# Altium schematic sheet RECEIVER DJI FPV SLAVE.SchDoc("RECEIVER DJI FPV SLAVE")
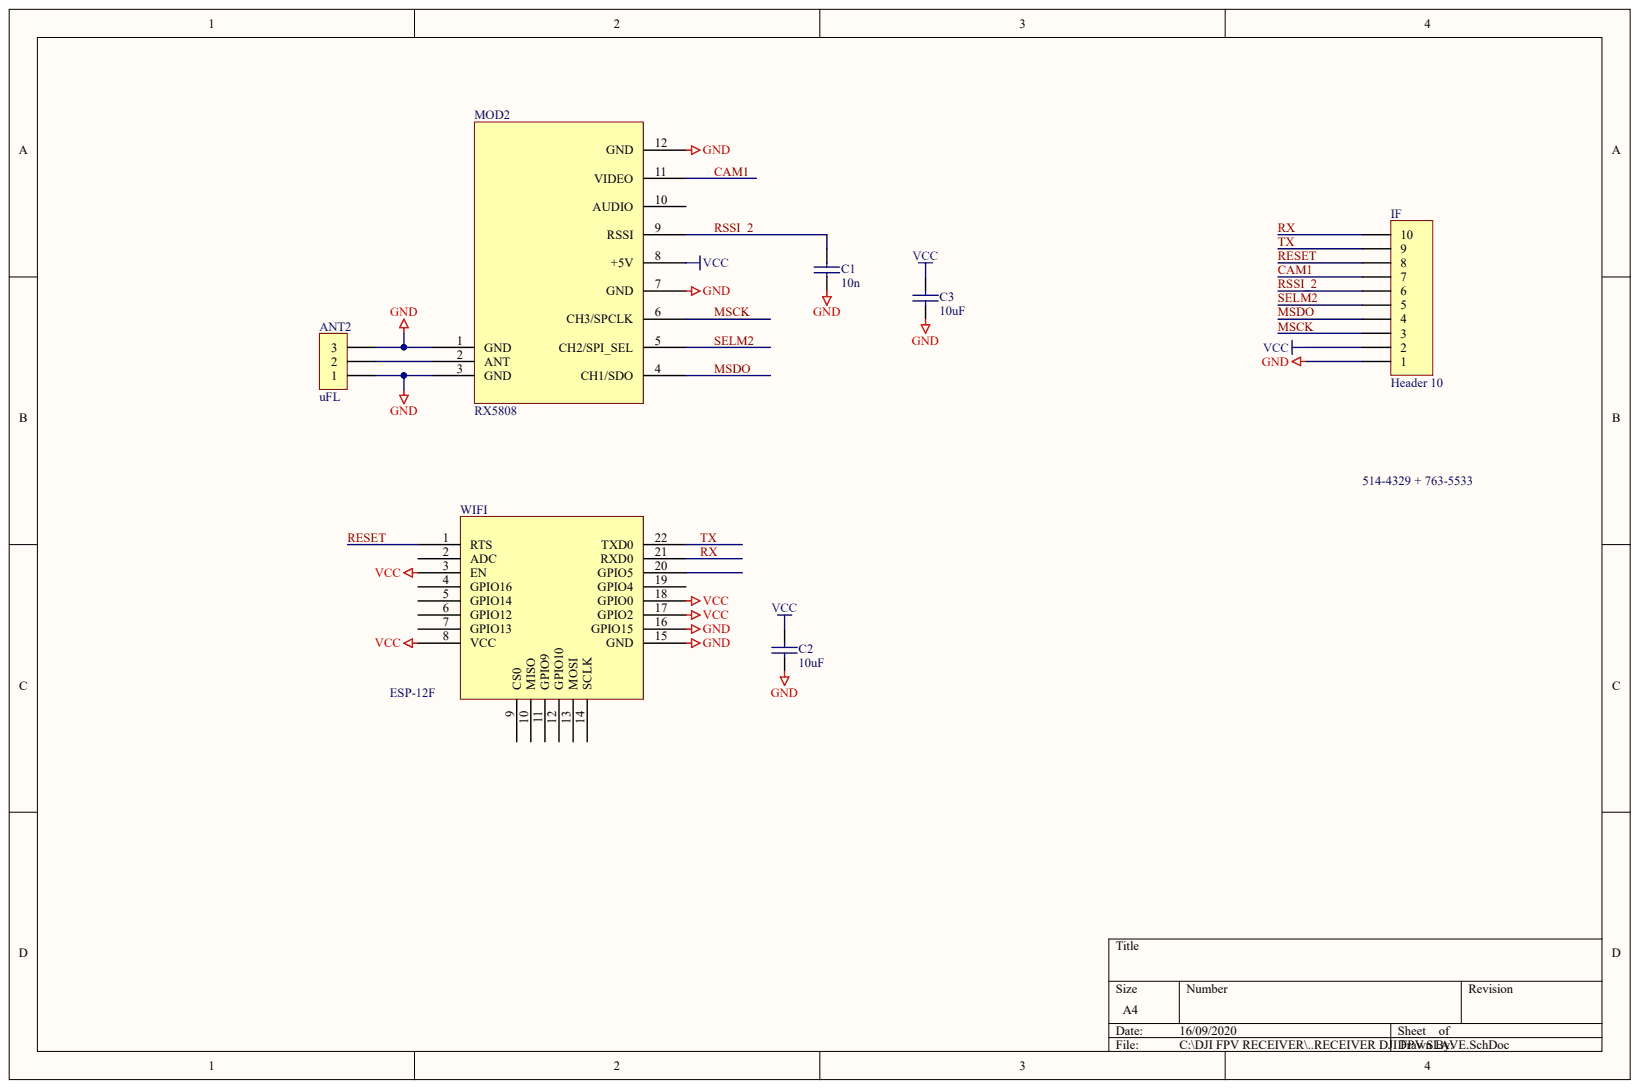
[diagram p0: RECEIVER DJI FPV SLAVE.SchDoc]

## Parts
ANT2  uFL  [ufl]
C1  10n  [0805]
C2  10uF  [0805]
C3  10uF  [0805]
IF  Header 10  [ziff10]
MOD2  RX5808  [rx5808s]
WIFI  ESP-12F  [ESP-12F]

## Nets
GND: ANT2.1 ANT2.3 C1.2 C2.2 C3.2 IF.1 MOD2.1(GND) MOD2.3(GND) MOD2.7(GND) MOD2.12(GND) WIFI.15(GND) WIFI.16(GPIO15)
VCC: C2.1 C3.1 IF.2 MOD2.8(+5V) WIFI.3(EN) WIFI.8(VCC) WIFI.17(GPIO2) WIFI.18(GPIO0)
CAM1: IF.7 MOD2.11(VIDEO)
MSCK: IF.3 MOD2.6(CH3/SPCLK)
MSDO: IF.4 MOD2.4(CH1/SDO)
RESET: IF.8 WIFI.1(RTS)
RSSI_2: C1.1 IF.6 MOD2.9(RSSI)
RX: IF.10 WIFI.21(RXD0)
SELM2: IF.5 MOD2.5(CH2/SPI_SEL)
TX: IF.9 WIFI.22(TXD0)
NetANT2_2: ANT2.2 MOD2.2(ANT)

Not connected: MOD2 10(AUDIO); WIFI 2(ADC) 4(GPIO16) 5(GPIO14) 6(GPIO12) 7(GPIO13) 9(CS0) 10(MISO) 11(GPIO9) 12(GPIO10) 13(MOSI) 14(SCLK) 19(GPIO4) 20(GPIO5)

## Library data of the parts
ANT2 = CON3: Connector; : 7839638; : 1.212; Component Kind: Standard; Library Name: btvtx var.IntLib
C1-C3 = CAP: Capacitor; Component Kind: Standard
IF = Header 10: Header, 10-Pin; Component Kind: Standard; LatestRevisionDate: 17-Jul-2002; LatestRevisionNote: Re-released for DXP Platform.; Library Name: Miscellaneous Connectors.IntLib; Publisher: Altium Limited
MOD2 = RX5808: Component Kind: Standard
WIFI = ESP-12F: Component Kind: Standard; Library Name: RECEIVER.SchLib
